# Altium schematic sheet Power_Supply.SchDoc(Power_Supply)
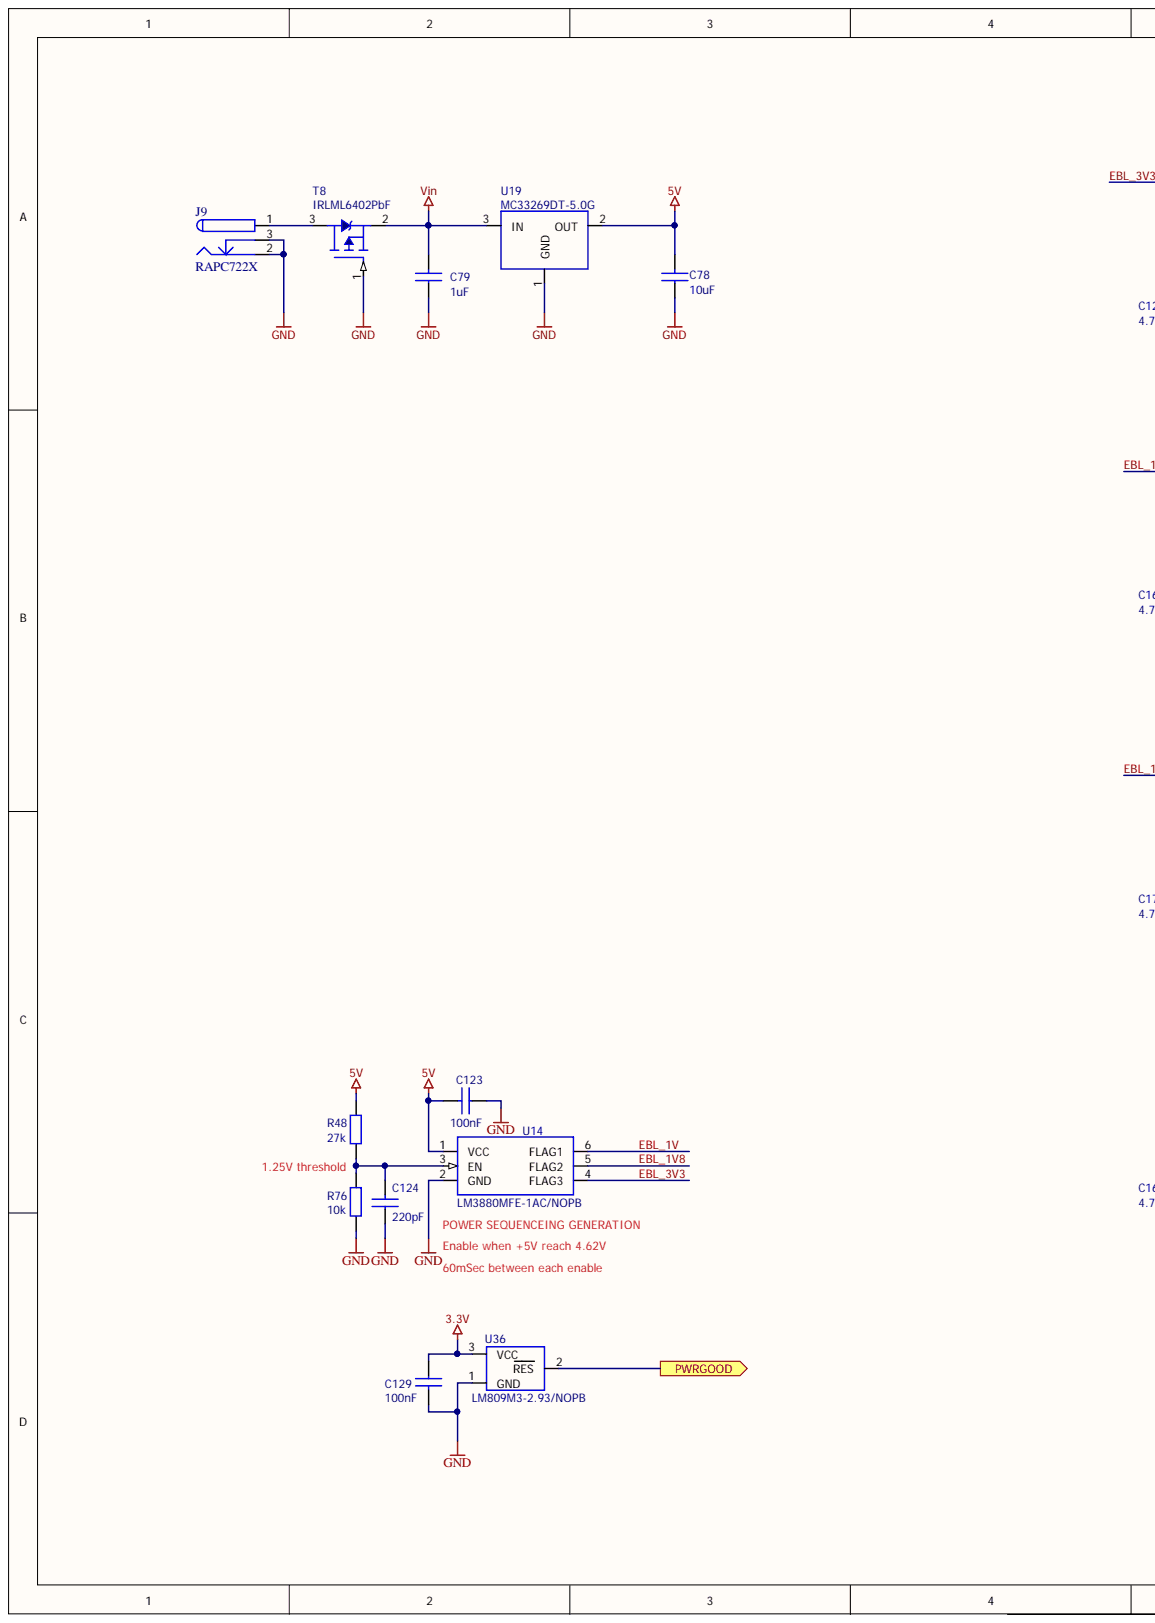
[diagram p0: Power_Supply.SchDoc, left]
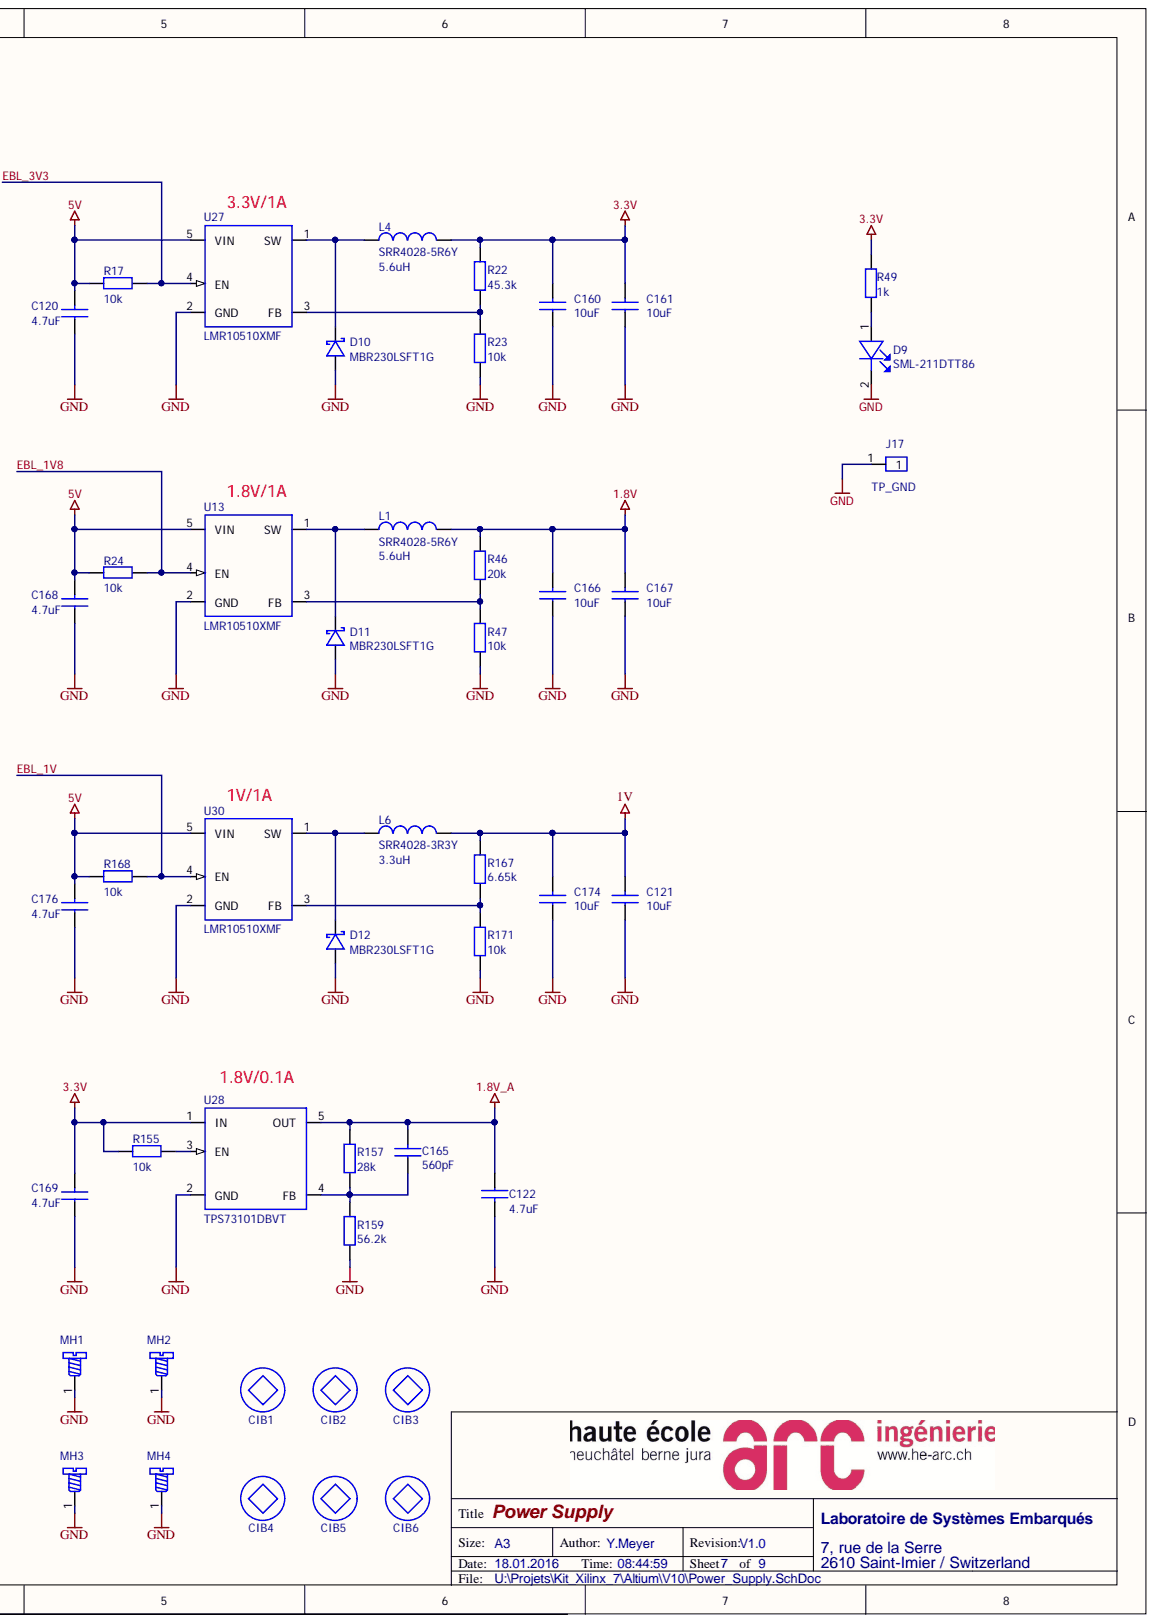
[diagram p1: Power_Supply.SchDoc, right]

## Parts
C78  value 10uF  [080501]  (hidden comment: C2012X6S1C106M125AC)
C79  value 1uF  [060301]  (hidden comment: C0603C105K4RACTU)
C120  value 4.7uF  [060301]  (hidden comment: C1608X5R0J475M080AB)
C121  value 10uF  [080501]  (hidden comment: C2012X6S1C106M125AC)
C122  value 4.7uF  [080501]  (hidden comment: GRM21BR71A475KA73)
C123  value 100nF  [060301]  (hidden comment: C0603C104Z3VAC7867)
C124  value 220pF  [060301]  (hidden comment: ECJ-1VC1H221J)
C129  value 100nF  [060301]  (hidden comment: C0603C104Z3VAC7867)
C160  value 10uF  [080501]  (hidden comment: C2012X6S1C106M125AC)
C161  value 10uF  [080501]  (hidden comment: C2012X6S1C106M125AC)
C165  value 560pF  [060301]  (hidden comment: C1608C0G1H561J080AA)
C166  value 10uF  [080501]  (hidden comment: C2012X6S1C106M125AC)
C167  value 10uF  [080501]  (hidden comment: C2012X6S1C106M125AC)
C168  value 4.7uF  [060301]  (hidden comment: C1608X5R0J475M080AB)
C169  value 4.7uF  [060301]  (hidden comment: C1608X5R0J475M080AB)
C174  value 10uF  [080501]  (hidden comment: C2012X6S1C106M125AC)
C176  value 4.7uF  [060301]  (hidden comment: C1608X5R0J475M080AB)
CIB1  CIBLE  [CIBLERON]  (value not drawn)
CIB2  CIBLE  [CIBLERON]  (value not drawn)
CIB3  CIBLE  [CIBLERON]  (value not drawn)
CIB4  CIBLE  [CIBLERON]  (value not drawn)
CIB5  CIBLE  [CIBLERON]  (value not drawn)
CIB6  CIBLE  [CIBLERON]  (value not drawn)
D9  SML-211DTT86  [0805_Led]
D10  MBR230LSFT1G  [SOD123LF]
D11  MBR230LSFT1G  [SOD123LF]
D12  MBR230LSFT1G  [SOD123LF]
J9  RAPC722X  [RAPC722]
J17  TP_GND  [J101C08]
L1  SRR4028-5R6Y  value 5.6uH  [SRR4028]
L4  SRR4028-5R6Y  value 5.6uH  [SRR4028]
L6  SRR4028-3R3Y  value 3.3uH  [SRR4028]
MH1  vis  [Mounting_hole]  (value not drawn)
MH2  vis  [Mounting_hole]  (value not drawn)
MH3  vis  [Mounting_hole]  (value not drawn)
MH4  vis  [Mounting_hole]  (value not drawn)
R17  value 10k  [060301]  (hidden comment: RC0603FR-0710KL)
R22  value 45.3k  [060301]  (hidden comment: ERJ-3EKF4532V)
R23  value 10k  [060301]  (hidden comment: RC0603FR-0710KL)
R24  value 10k  [060301]  (hidden comment: RC0603FR-0710KL)
R46  value 20k  [060301]  (hidden comment: ERJ-3EKF2002V)
R47  value 10k  [060301]  (hidden comment: RC0603FR-0710KL)
R48  value 27k  [060301]  (hidden comment: ERJ-3EKF2702V)
R49  value 1k  [060301]  (hidden comment: RC0603FR-071KL)
R76  value 10k  [060301]  (hidden comment: RC0603FR-0710KL)
R155  value 10k  [060301]  (hidden comment: RC0603FR-0710KL)
R157  value 28k  [060301]  (hidden comment: ERJ-3EKF2802V)
R159  value 56.2k  [060301]  (hidden comment: ERJ-3EKF5622V)
R167  value 6.65k  [060301]  (hidden comment: RC0603FR-076K65L)
R168  value 10k  [060301]  (hidden comment: RC0603FR-0710KL)
R171  value 10k  [060301]  (hidden comment: RC0603FR-0710KL)
T8  IRLML6402PbF  [SOT2301]
U13  LMR10510XMF  [SOT23-5]
U14  LM3880MFE-1AC/NOPB  [SOT23601]
U19  MC33269DT-5.0G  [DPACK]
U27  LMR10510XMF  [SOT23-5]
U28  TPS73101DBVT  [SOT23-5]
U30  LMR10510XMF  [SOT23-5]
U36  LM809M3-2.93/NOPB  [SOT2301]

## Ports
PWRGOOD

## Nets
1.8V: C166.2 C167.2 L1.2 R46.1
1.8V_A: C122.1 C165.2 R157.1 U28.5(OUT)
1V: C121.2 C174.2 L6.2 R167.1
3.3V: C129.1 C160.2 C161.2 C169.2 L4.2 R22.1 R49.2 R155.1 U28.1(IN) U36.3
5V: C78.2 C120.2 C123.2 C168.2 C176.2 R17.1 R24.1 R48.1 R168.1 U13.5(VIN) U14.1(VCC) U19.2 U27.5(VIN) U30.5(VIN)
GND: C78.1 C79.1 C120.1 C121.1 C122.2 C123.1 C124.1 C129.2 C160.1 C161.1 C166.1 C167.1 C168.1 C169.1 C174.1 C176.1 D9.2 D10.2 D11.2 D12.2 J9.2 J9.3 J17.1 MH1.1 MH2.1 MH3.1 MH4.1 R23.2 R47.2 R76.2 R159.2 R171.2 T8.1 U13.2(GND) U14.2(GND) U19.1 U27.2(GND) U28.2(GND) U30.2(GND) U36.1
VIN: C79.2 T8.2 U19.3
EBL_1V: R168.2 U14.6(FLAG1) U30.4(EN)
EBL_1V8: R24.2 U13.4(EN) U14.5(FLAG2)
EBL_3V3: R17.2 U14.4(FLAG3) U27.4(EN)
PWRGOOD: U36.2 ; port PWRGOOD
NetC124_2: C124.2 R48.2 R76.1 U14.3(EN)
NetC165_1: C165.1 R157.2 R159.1 U28.4(FB)
NetD9_1: D9.1 R49.1
NetD10_1: D10.1 L4.1 U27.1(SW)
NetD11_1: D11.1 L1.1 U13.1(SW)
NetD12_1: D12.1 L6.1 U30.1(SW)
NetJ9_1: J9.1 T8.3
NetR22_2: R22.2 R23.1 U27.3(FB)
NetR46_2: R46.2 R47.1 U13.3(FB)
NetR155_2: R155.2 U28.3(EN)
NetR167_2: R167.2 R171.1 U30.3(FB)

## Library data of the parts
C78 C121 C160 C161 C166 C167 C174 = CAPA: CAP CER 10UF 16V 20% X6S 0805; Component Kind: Standard; Supplier 1: Digi-Key; Supplier Part Number 1: 445-7612-2-ND; Library Name: EISI.SchLib
C79 = CAPA: CAP CER 1UF 16V 10% X7R 0603; Component Kind: Standard; Supplier 1: Digi-Key; Supplier Part Number 1: 399-7847-6-ND; Library Name: EISI.SchLib
C120 C168 C169 C176 = CAPA: CAP CER 4.7UF 6.3V 20% X5R 0603; Component Kind: Standard; Supplier 1: Digi-Key; Supplier Part Number 1: 445-1417-2-ND; Library Name: EISI.SchLib
C122 = CAPA: CAP CER 4.7UF 10V X7R 0805; Component Kind: Standard; Supplier 1: Digi-Key; Supplier Part Number 1: 490-6480-6-ND; Library Name: EISI.SchLib
C123 C129 = CAPACITOR NON-POL_Dup2: CAP .10UF 25V CERAMIC Y5V 0603; Component Kind: Standard; Supplier 1: Digi-Key; Supplier Part Number 1: 399-1100-2-ND
C124 = CAPA: CAP CER 220PF 50V 5% NP0 0603; Component Kind: Standard; Supplier 1: Digi-Key; Supplier Part Number 1: PCC221ACVTR-ND; Library Name: EISI.SchLib
C165 = CAPA: CAP CER 560PF 50V 5% NP0 0603; Component Kind: Standard; Supplier 1: Digi-Key; Supplier Part Number 1: 445-1290-2-ND; Library Name: EISI.SchLib
CIB1-CIB6 = CIBLE: Cible de positionnement pour montage PCB; Component Kind: Standard; Library Name: StartTimeV.SCHLIB
D9 = LED: LED 611NM ORN WTR CLR 0805 SMD; Component Kind: Standard; Supplier 1: Digi-Key; Supplier Part Number 1: 511-1291-6-ND; Library Name: EISI.SchLib
D10-D12 = MBR230LSFT1G: DIODE SCHOTTKY 30V 2A SOD123L; Component Kind: Standard; Library Name: PowerBoard.SchLib; Supplier 1: Digi-Key; Supplier Part Number 1: MBR230LSFT1GOSCT-ND
J9 = JACK_PWR: Power jack 2.1mm center pin; Component Kind: Standard; Signal Integrity: Connector; Supplier 1: Digi-Key; Supplier Part Number 1: SC1313-ND; Library Name: EISI.SchLib
J17 = CON1: TP; Component Kind: Standard; Library Name: EISI.SchLib
L1 L4 = SRR4028-2R2Y: Shielded Power Inductor; Component Kind: Standard; Library Name: PowerBoard.SchLib; Supplier 1: Digi-Key; Supplier Part Number 1: SRR4028-5R6YCT-ND
L6 = SRR4028-6R8Y: Shielded Power Inductor; Component Kind: Standard; Library Name: PowerBoard.SchLib; Supplier 1: Digi-Key; Supplier Part Number 1: SRR4028-3R3YCT-ND
MH1-MH4 = vis: Mounting Hole 3.5mm; Component Kind: Standard; Library Name: ServoDrive.SCHLIB
R17 R23 R24 R47 R76 R155 R168 R171 = RES: RES 10.0K OHM 1/10W 1% 0603 SMD; Component Kind: Standard; Supplier 1: Digi-Key; Supplier Part Number 1: 311-10.0KHRCT-ND; Library Name: EISI.SchLib
R22 = RES: RES 45.3K OHM 1/10W 1% 0603 SMD; Component Kind: Standard; Supplier 1: Digi-Key; Supplier Part Number 1: P45.3KHTR-ND; Library Name: EISI.SchLib
R46 = RES: RES 20K OHM 1/10W 1% 0603 SMD; Component Kind: Standard; Supplier 1: Digi-Key; Supplier Part Number 1: P20.0KHTR-ND; Library Name: EISI.SchLib
R48 = RES: RES SMD 27K OHM 1% 1/10W 0603; Component Kind: Standard; Supplier 1: Digi-Key; Supplier Part Number 1: ERJ-3EKF2702V; Library Name: EISI.SchLib
R49 = RES: RES SMD 1K OHM 1% 1/10W 0603; Component Kind: Standard; Supplier 1: Digi-Key; Supplier Part Number 1: 311-1.00KHRCT-ND; Library Name: EISI.SchLib
R157 = RES: RES 28K OHM 1/10W 1% 0603 SMD; Component Kind: Standard; Supplier 1: Digi-Key; Supplier Part Number 1: P28.0KHTR-ND; Library Name: EISI.SchLib
R159 = RES: RES 56.2K OHM 1/10W 1% 0603 SMD; Component Kind: Standard; Supplier 1: Digi-Key; Supplier Part Number 1: P56.2KHTR-ND; Library Name: EISI.SchLib
R167 = RES: RES SMD 6.65K OHM 1% 1/10W 0603; Component Kind: Standard; Supplier 1: Digi-Key; Supplier Part Number 1: 311-6.65KHRCT-ND; Library Name: EISI.SchLib
T8 = IRLML5103: MOSFET P-CH 20V 3.7A SOT-23; Component Kind: Standard; Supplier 1: Digi-Key; Supplier Part Number 1: IRLML6402PBFCT-ND; Library Name: EISI.SchLib
U13 U27 U30 = LMR10510XMF: IC REG BUCK ADJ 1A SOT23-5; Component Kind: Standard; Library Name: ServoDrive.SCHLIB; Supplier 1: Digi-Key; Supplier Part Number 1: LMR10510XMFE/NOPBTR-ND
U14 = LM3880: IC PWR SUPPLY SEQUENCER SOT23-6; Component Kind: Standard; Library Name: ServoDrive.SCHLIB; Supplier 1: Digi-Key; Supplier Part Number 1: LM3880MFE-1AC/NOPBDKR-ND
U19 = MC33275: IC REG LDO 5V 0.8A DPAK; Component Kind: Standard; Supplier 1: Digi-Key; Supplier Part Number 1: MC33269DT-5.0GOS-ND; Library Name: EISI.SchLib
U28 = TPS73101DBV: IC REG LDO ADJ 0.15A SOT23-5; Component Kind: Standard; Supplier 1: Digi-Key; Supplier Part Number 1: 296-15800-2-ND; Library Name: EISI.SchLib
U36 = LM809M3: uP reset circuit; Component Kind: Standard; Library Name: ServoDrive.SCHLIB; Supplier 1: Digi-Key; Supplier Part Number 1: LM809M3-2.93/NOPBCT-ND
